# Altium schematic sheet schematic.SchDoc(schematic)
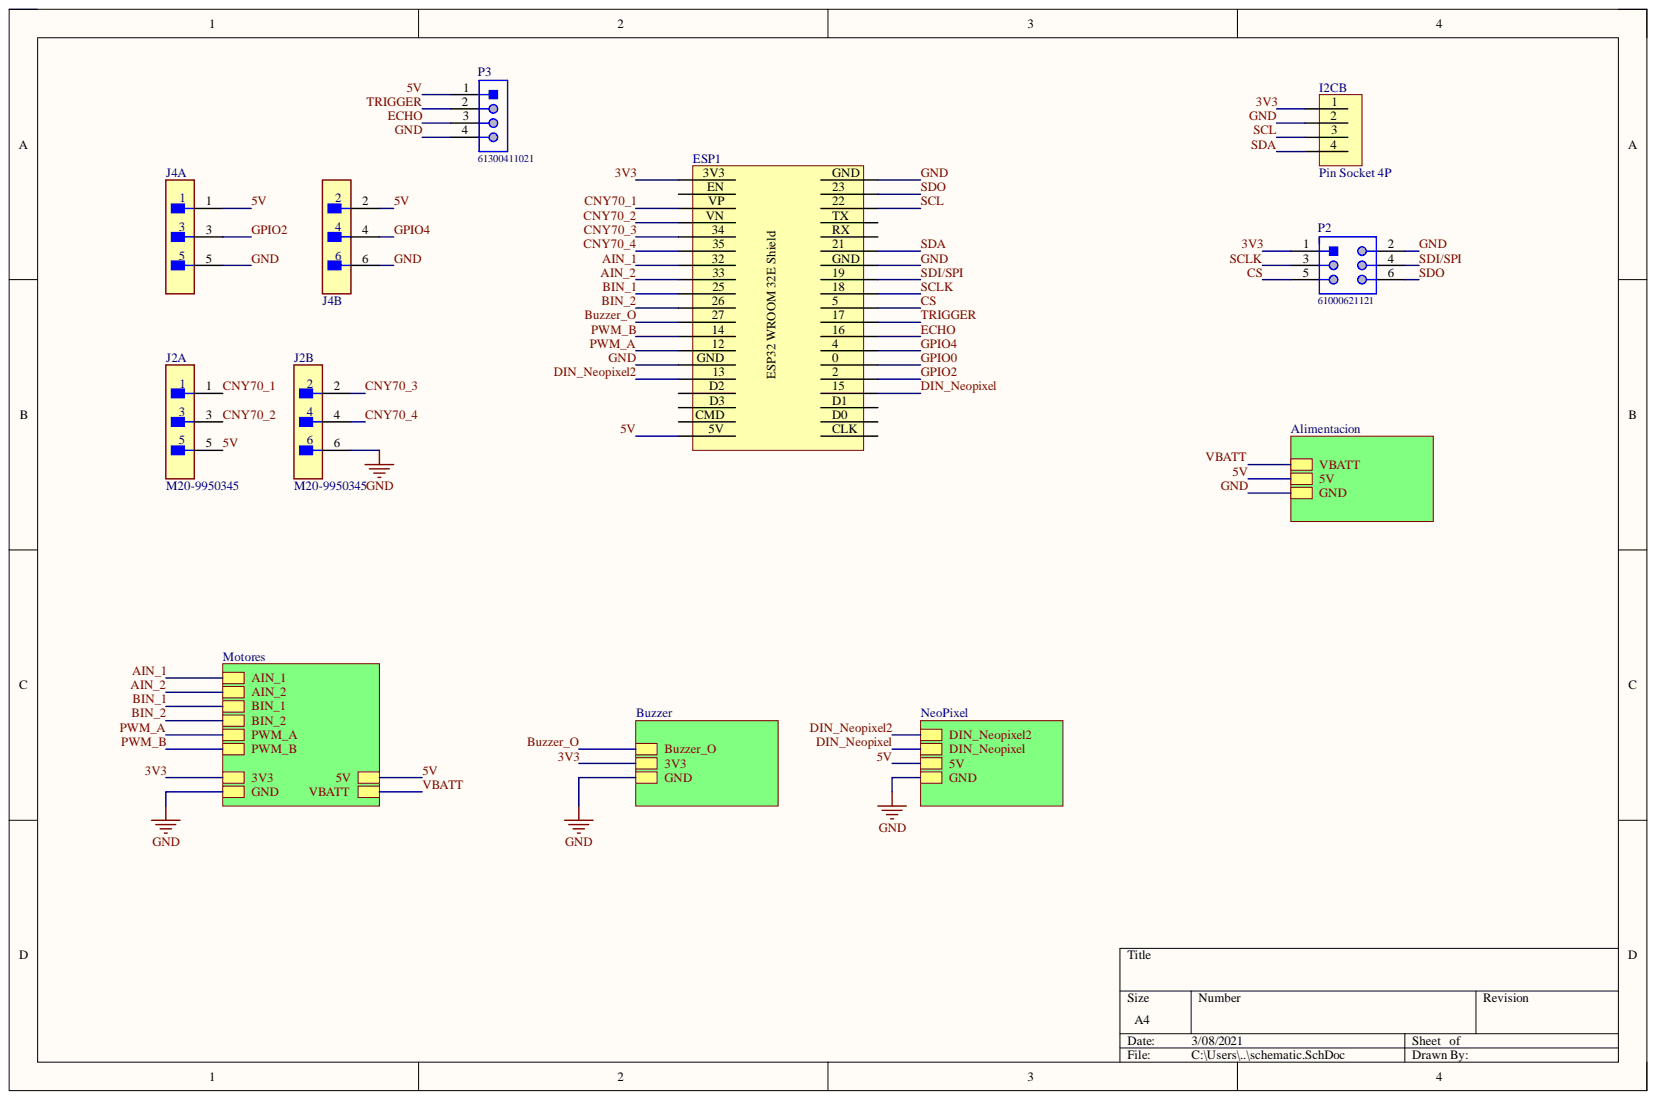
[diagram p0: schematic.SchDoc]

## Parts
ESP1  Shield_ESP32_WR32E  [Shield_ESP32_WR32E]  (value not drawn)
I2C  Pin Socket 4P  [Pin Socket 4P]  (part B)
J2  M20-9950345  [FP-M20-9950345-MFG]  (parts A,B)
J4  M20-9950345  [FP-M20-9950345-MFG]  (parts A,B)
P2  61000621121  [61000621121]
P3  61300411021  [61300411021]

## Nets
3V3: ESP1.3V3 I2C.1 P2.1
5V: ESP1.5V J2.5 J4.1 J4.2 P3.1
AIN_1: ESP1.32
AIN_2: ESP1.33
BIN_1: ESP1.25
BIN_2: ESP1.26
Buzzer_O: ESP1.27
CNY70_1: ESP1.VP J2.1
CNY70_2: ESP1.VN J2.3
CNY70_3: ESP1.34 J2.2
CNY70_4: ESP1.35 J2.4
CS: ESP1.5 P2.5
DIN_Neopixel: ESP1.15
DIN_Neopixel2: ESP1.13
ECHO: ESP1.16 P3.3
GND: ESP1.GND I2C.2 J2.6 J4.5 J4.6 P2.2 P3.4
GPIO0: ESP1.0
GPIO2: ESP1.2 J4.3
GPIO4: ESP1.4 J4.4
PWM_A: ESP1.12
PWM_B: ESP1.14
SCL: ESP1.22 I2C.3
SCLK: ESP1.18 P2.3
SDA: ESP1.21 I2C.4
SDI/SPI: ESP1.19 P2.4
SDO: ESP1.23 P2.6
TRIGGER: ESP1.17 P3.2
NetESP1_CLK: ESP1.CLK
NetESP1_CMD: ESP1.CMD
NetESP1_D0: ESP1.D0
NetESP1_D1: ESP1.D1
NetESP1_D2: ESP1.D2
NetESP1_D3: ESP1.D3
NetESP1_EN: ESP1.EN
NetESP1_RX: ESP1.RX
NetESP1_TX: ESP1.TX
VBATT:

## Library data of the parts
I2C = Pin Socket 4P: Female Header 4 1 Straight，180degrees 2.54mm Through Hole,P=2.54mm Pin Header & Female Header RoHS; Component Kind: Standard; Library Name: Isidro_Library.SchLib
J2 J4 = CMP-15831-000002-1: CONN HEADER R/A 6POS 2.54MM; Manufacturer: Harwin; Conn Gender: Male; Conn Orientation: Right Angle; Current Rating: 3A; Number Of Contacts: 6; Automotive: No; Category: Conn; Component Kind: Standard; ComponentLink2Description: Datasheet; ComponentLink2URL: https://cdn.harwin.com/pdfs/M20-995.pdf; Contact Material: Gold,Nickel; Device Class L1: Connectors; Device Class L2: Headers and Wire Housings; Device Class L3: unset; Digikey Description: CONN HEADER R/A 6POS 2.54MM; Height: 5.28mm; Ipc Land Pattern Name: DFN400X400X80-14; Lead Free: Yes; Library Name: Altium Content Vault; Manufacturer Part Number: M20-9950345; Mouser Description: Headers & Wire Housings 03+03 DIL HORIZONTAL PIN HEADER GOLD HT; Number Of Rows: 2; Octopart: https://octopart.com/m20-9950345-harwin-21265213; Package: 0; Pitch: 2.54mm; RoHS: TRUE; Temperature: -40°C to +105°C; Temperature Range High: +105°C; Temperature Range Low: -40°C
P2 = CMP-1502-01024-1: SMT Vertical Pin Header WR-PHD, Pitch 2.54 mm, Dual Row, 6 pins; Manufacturer: Wurth Elektronik; Contact Resistance: 20mOhm; Gender: Male; Pin Number: 6; Pitch: 2.54mm; Rated Current: 3A; Withstanding Voltage: 500VAC; Working Voltage: 250VAC; Component Kind: Standard; Datasheet URL: https://katalog.we-online.de/em/datasheet/6100xx21121.pdf; Library Name: Altium Content Vault; Manufacturer URL: http://www.we-online.de; Mounting Technology: SMT; Operating Temperature: -40to125degC; Orientation: Vertical; Package Reference: 61000621121; Packaging: Tube; Part Number: 61000621121; Row Number: Dual; Publisher: WurthElektronik
P3 = CMP-1502-01063-1: THT Angled Pin Header WR-PHD, Pitch 2.54 mm, Single Row, 4 pins; Manufacturer: Wurth Elektronik; Contact Resistance: 20mOhm; Gender: Male; Pin Number: 4; Pitch: 2.54mm; Rated Current: 3A; Withstanding Voltage: 500VAC; Working Voltage: 250VAC; Component Kind: Standard; Datasheet URL: https://katalog.we-online.de/em/datasheet/6130xx11021.pdf; Library Name: Altium Content Vault; Manufacturer URL: http://www.we-online.de; Mounting Technology: THT; Operating Temperature: -40to105degC; Orientation: Angled; Package Reference: 61300411021; Packaging: Bag; Part Number: 61300411021; Row Number: Single; Publisher: WurthElektronik
ESP1 = Shield_ESP32_WR32E: Component Kind: Standard; Library Name: Isidro_Library.SchLib
